# Altium schematic sheet Current_A2V3.SchDoc
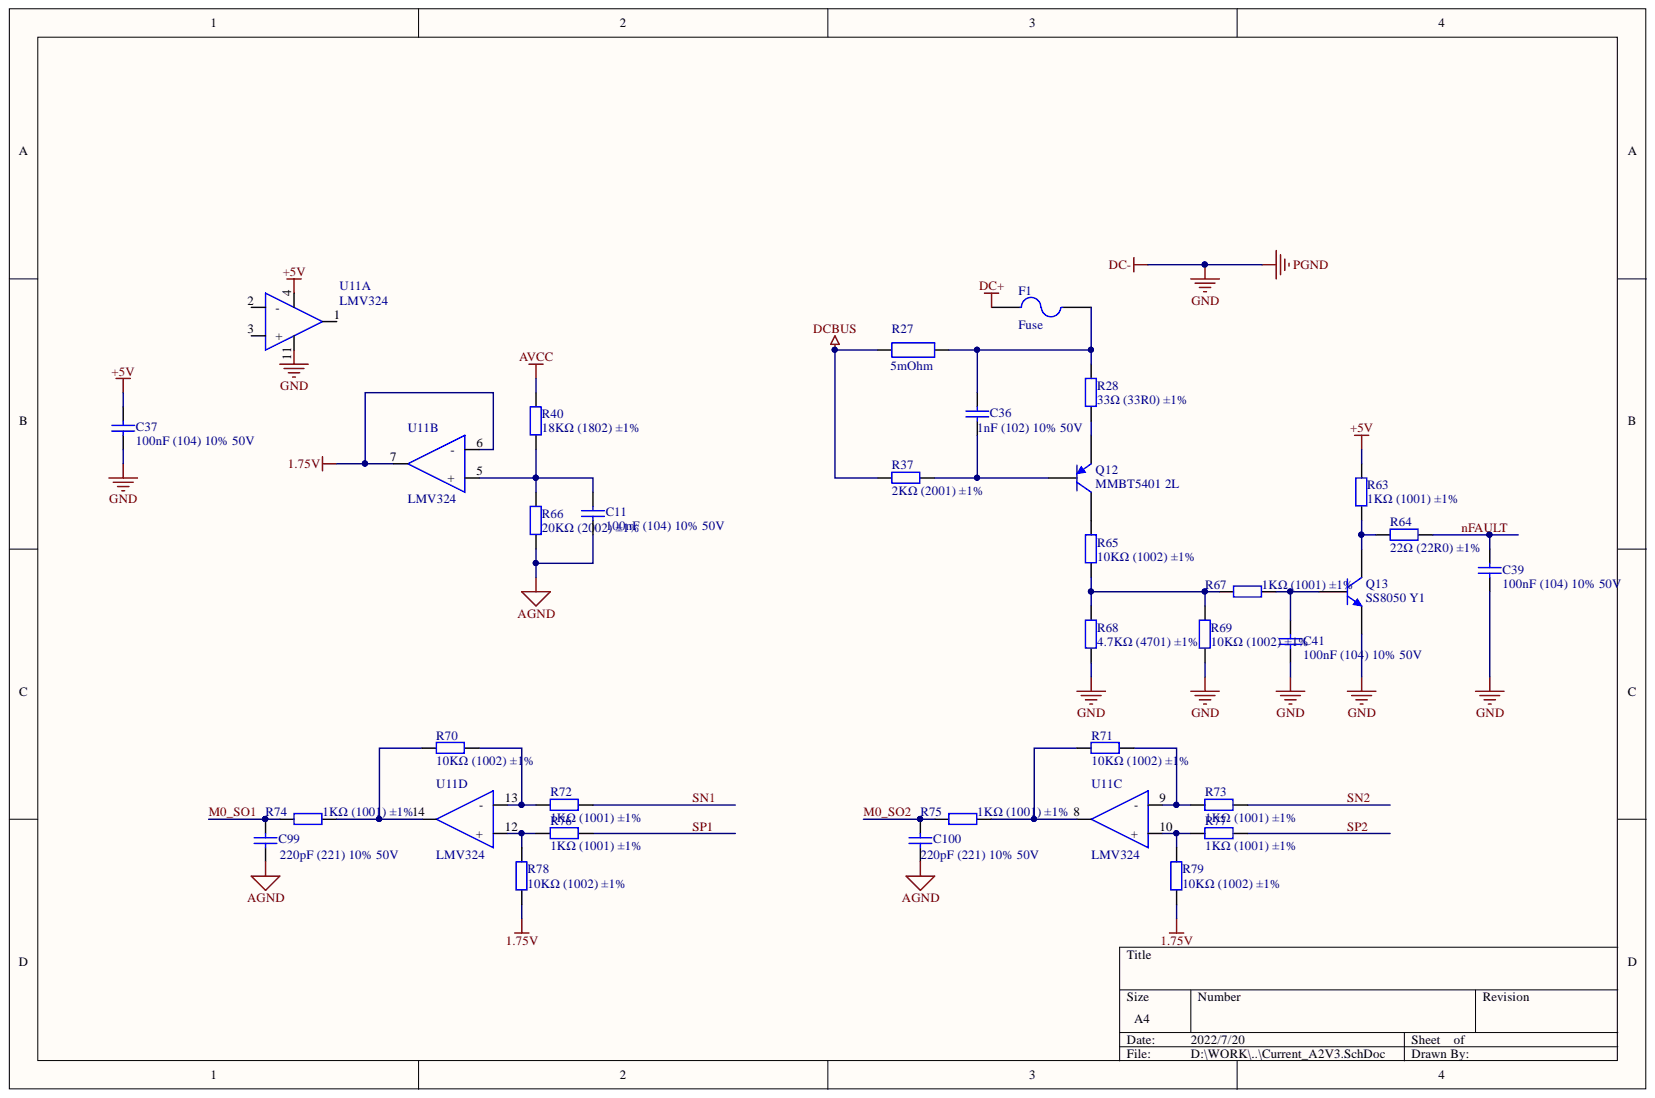
[diagram p0: Current_A2V3.SchDoc]

## Parts
C11  100nF (104) 10% 50V  [0603_C]
C36  1nF (102) 10% 50V  [0603_C]
C37  100nF (104) 10% 50V  [0603_C]
C39  100nF (104) 10% 50V  [0603_C]
C41  100nF (104) 10% 50V  [0603_C]
C99  220pF (221) 10% 50V  [0603_C]
C100  220pF (221) 10% 50V  [0603_C]
F1  Fuse  [SMD]
Q12  MMBT5401 2L  [SOT-23(SOT-23-3)]
Q13  SS8050 Y1  [SOT-23(SOT-23-3)]
R27  value 5mOhm  [RESC6432X09N]  (hidden comment: 500uOhm)
R28  33Ω (33R0) ±1%  [0805_R]
R37  2KΩ (2001) ±1%  [0603_R]
R40  18KΩ (1802) ±1%  [0603_R]
R63  1KΩ (1001) ±1%  [0603_R]
R64  22Ω (22R0) ±1%  [0603_R]
R65  10KΩ (1002) ±1%  [0805_R]
R66  20KΩ (2002) ±1%  [0603_R]
R67  1KΩ (1001) ±1%  [0603_R]
R68  4.7KΩ (4701) ±1%  [0603_R]
R69  10KΩ (1002) ±1%  [0603_R]
R70  10KΩ (1002) ±1%  [0603_R]
R71  10KΩ (1002) ±1%  [0603_R]
R72  1KΩ (1001) ±1%  [0603_R]
R73  1KΩ (1001) ±1%  [0603_R]
R74  1KΩ (1001) ±1%  [0603_R]
R75  1KΩ (1001) ±1%  [0603_R]
R76  1KΩ (1001) ±1%  [0603_R]
R77  1KΩ (1001) ±1%  [0603_R]
R78  10KΩ (1002) ±1%  [0603_R]
R79  10KΩ (1002) ±1%  [0603_R]
U11  LMV324  [TSSOP14_N]  (parts A,B,C,D)

## Nets
1.75V: R78.1 R79.2 U11.6(-) U11.7
+5V: C37.2 R63.2 U11.4
AGND: C11.1 C99.1 C100.1 R66.1
AVCC: R40.2
DC+: F1.2
DC-: C37.1 C39.1 C41.1 Q13.2 R68.1 R69.1 U11.11
DCBUS: R27.2 R37.1
M0_SO1: C99.2 R74.1
M0_SO2: C100.2 R75.1
NFAULT: C39.2 R64.2
SN1: R72.2
SN2: R73.2
SP1: R76.2
SP2: R77.2
NetC11_2: C11.2 R40.1 R66.2 U11.5(+)
NetC36_1: C36.1 Q12.1 R37.2
NetC36_2: C36.2 F1.1 R27.1 R28.2
NetC41_2: C41.2 Q13.1 R67.2
NetQ12_2: Q12.2 R28.1
NetQ12_3: Q12.3 R65.2
NetQ13_3: Q13.3 R63.1 R64.1
NetR65_1: R65.1 R67.1 R68.2 R69.2
NetR70_1: R70.1 R74.2 U11.14
NetR70_2: R70.2 R72.1 U11.13(-)
NetR71_1: R71.1 R75.2 U11.8
NetR71_2: R71.2 R73.1 U11.9(-)
NetR76_1: R76.1 R78.2 U11.12(+)
NetR77_1: R77.1 R79.1 U11.10(+)

Not connected: U11 1 2(-) 3(+)

## Library data of the parts
C11 C37 C39 C41 = 0603 100nF (104) 10% 50V: 贴片电容; Component Kind: Standard; ComponentLink1Description: 供应商链接; ComponentLink1URL: http://www.szlcsc.com/product/details_15331.html; Library Name: Cap.SchLib; Package: 0603; Supplier: LC; SuppliersPartNumber: C14663
C36 = 0603 1nF (102) 10% 50V: 贴片电容; Component Kind: Standard; ComponentLink1Description: 供应商链接; ComponentLink1URL: http://www.szlcsc.com/product/details_1940.html; Library Name: Cap.SchLib; Package: 0603; Supplier: LC; SuppliersPartNumber: C1588
C99 C100 = 0603 220pF (221) 10% 50V: 贴片电容; Component Kind: Standard; ComponentLink1Description: 供应商链接; ComponentLink1URL: http://www.szlcsc.com/product/details_1955.html; Library Name: Cap.SchLib; Package: 0603; Supplier: LC; SuppliersPartNumber: C1603
F1 = Fuse 2: Component Kind: Standard; Description: Fuse; LatestRevisionDate: 17-Jul-2002; LatestRevisionNote: Re-released for DXP Platform.; Library Name: Miscellaneous Devices.IntLib; PackageDescription: Thru-Hole; 2 Leads; PackageReference: PIN-W2/E2.8; Published: 8-Jun-2000; Simulation: FUSE; Publisher: Altium Limited
Q12 = SOT-23 MMBT5401 2L: 三极管; Component Kind: Standard; ComponentLink1Description: 供应商链接; ComponentLink1URL: http://www.szlcsc.com/product/details_8818.html; Library Name: Transistor_PNP.SchLib; Package: SOT-23; Supplier: LC; SuppliersPartNumber: C8326
Q13 = SOT-23 SS8050 Y1: 三极管; Component Kind: Standard; ComponentLink1Description: 供应商链接; ComponentLink1URL: http://www.szlcsc.com/product/details_2507.html; Library Name: Transistor_NPN.SchLib; Package: SOT-23; Supplier: LC; SuppliersPartNumber: C2150
R27 = CSR2512C0R0005F: RES SMD 0.0005 OHM 1% 3W 2512; Manufacturer: Riedon; Temperature Coefficient: ±100ppm/°C; Category: Resistors; Component Kind: Standard; ComponentLink1Description: http://riedon.com/media/pdf/CSR.pdf; ComponentLink1URL: http://riedon.com/media/pdf/CSR.pdf; Composition: Metal Element; Failure Rate: -; Features: Current Sense; Height - Seated (Max): 0.057" (1.45mm); Library Name: CustomComponents.SchLib; Manufacturer Part Number: CSR2512C0R0005F; Operating Temperature: -55°C ~ 170°C; Package / Case: 2512 (6432 Metric); Packaging: Tape & Reel (TR); Part Status: Active; Power (Watts): 3W; Pricing 1: 2000=0.19817, 6000=0.1937, 10000=0.187, 50000=0.18148 (USD); Pricing 2: 1=0.69, 10=0.574, 25=0.4916, 50=0.4426, 100=0.3852, 250=0.3442, 500=0.27864, 1000=0.22536 (USD); RoHS: RoHS Compliant; Size / Dimension: 0.250" L x 0.118" W (6.35mm x 3.00mm); Stock: 2000; Supplier 1: Digi-Key; Supplier 2: Digi-Key; Supplier Device Package: 2512; Supplier Part Number 1: 696-1183-2-ND; Supplier Part Number 2: 696-1183-1-ND; Tolerance: ±1%
R28 = 0805 33Ω (33R0) 1%: 贴片电阻; Component Kind: Standard; ComponentLink1Description: 供应商链接; ComponentLink1URL: http://www.szlcsc.com/product/details_18322.html; Library Name: Miscellaneous Devices LC.IntLib; Package: 0805; Supplier: LC; SuppliersPartNumber: C17634
R37 = 0603 2KΩ (2001) 1%: 贴片电阻; Component Kind: Standard; ComponentLink1Description: 供应商链接; ComponentLink1URL: http://www.szlcsc.com/product/details_23702.html; Library Name: RES.SchLib; Package: 0603; Supplier: LC; SuppliersPartNumber: C22975
R40 = 0603 18KΩ (1802) 1%: 贴片电阻; Component Kind: Standard; ComponentLink1Description: 供应商链接; ComponentLink1URL: http://www.szlcsc.com/product/details_26553.html; Library Name: RES.SchLib; Package: 0603; Supplier: LC; SuppliersPartNumber: C25810
R63 R67 R72-R77 = 0603 1KΩ (1001) 1%: 贴片电阻; Component Kind: Standard; ComponentLink1Description: 供应商链接; ComponentLink1URL: http://www.szlcsc.com/product/details_21904.html; Library Name: RES.SchLib; Package: 0603; Supplier: LC; SuppliersPartNumber: C21190
R64 = 0603 22Ω (22R0) 1%: 贴片电阻; Component Kind: Standard; ComponentLink1Description: 供应商链接; ComponentLink1URL: http://www.szlcsc.com/product/details_24078.html; Library Name: RES.SchLib; Package: 0603; Supplier: LC; SuppliersPartNumber: C23345
R65 = 0805 10KΩ (1002) 1%: 贴片电阻; Component Kind: Standard; ComponentLink1Description: 供应商链接; ComponentLink1URL: http://www.szlcsc.com/product/details_18102.html; Library Name: RES.SchLib; Package: 0805; Supplier: LC; SuppliersPartNumber: C17414
R66 = 0603 20KΩ (2002) 1%: 贴片电阻; Component Kind: Standard; ComponentLink1Description: 供应商链接; ComponentLink1URL: http://www.szlcsc.com/product/details_4591.html; Library Name: RES.SchLib; Package: 0603; Supplier: LC; SuppliersPartNumber: C4184
R68 = 0603 4.7KΩ (4701) 1%: 贴片电阻; Component Kind: Standard; ComponentLink1Description: 供应商链接; ComponentLink1URL: http://www.szlcsc.com/product/details_23889.html; Library Name: RES.SchLib; Package: 0603; Supplier: LC; SuppliersPartNumber: C23162
R69-R71 R78 R79 = 0603 10KΩ (1002) 1%: 贴片电阻; Component Kind: Standard; ComponentLink1Description: 供应商链接; ComponentLink1URL: http://www.szlcsc.com/product/details_26547.html; Library Name: RES.SchLib; Package: 0603; Supplier: LC; SuppliersPartNumber: C25804
U11 = LMV324: Component Kind: Standard; Library Name: MYLIB.SchLib
